# Altium schematic sheet Output_2.SchDoc(Output_2)
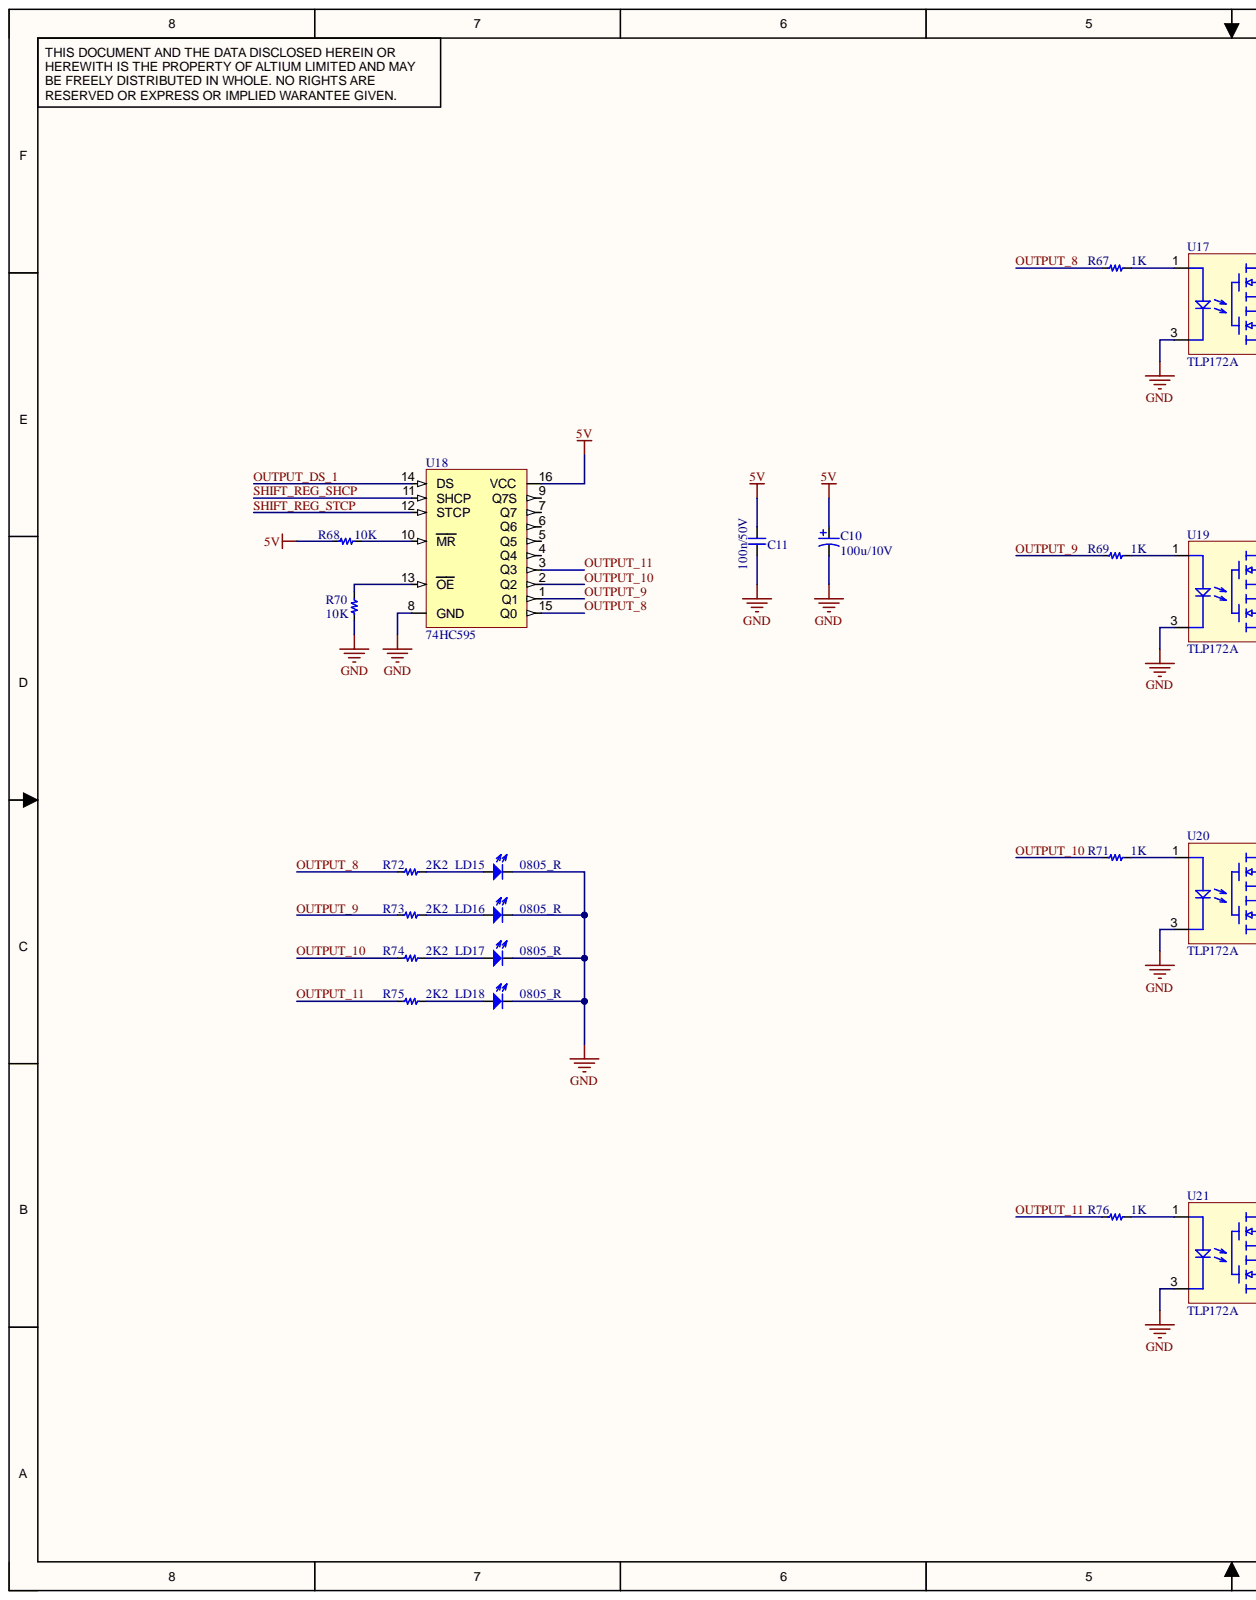
[diagram p0: Output_2.SchDoc, left]
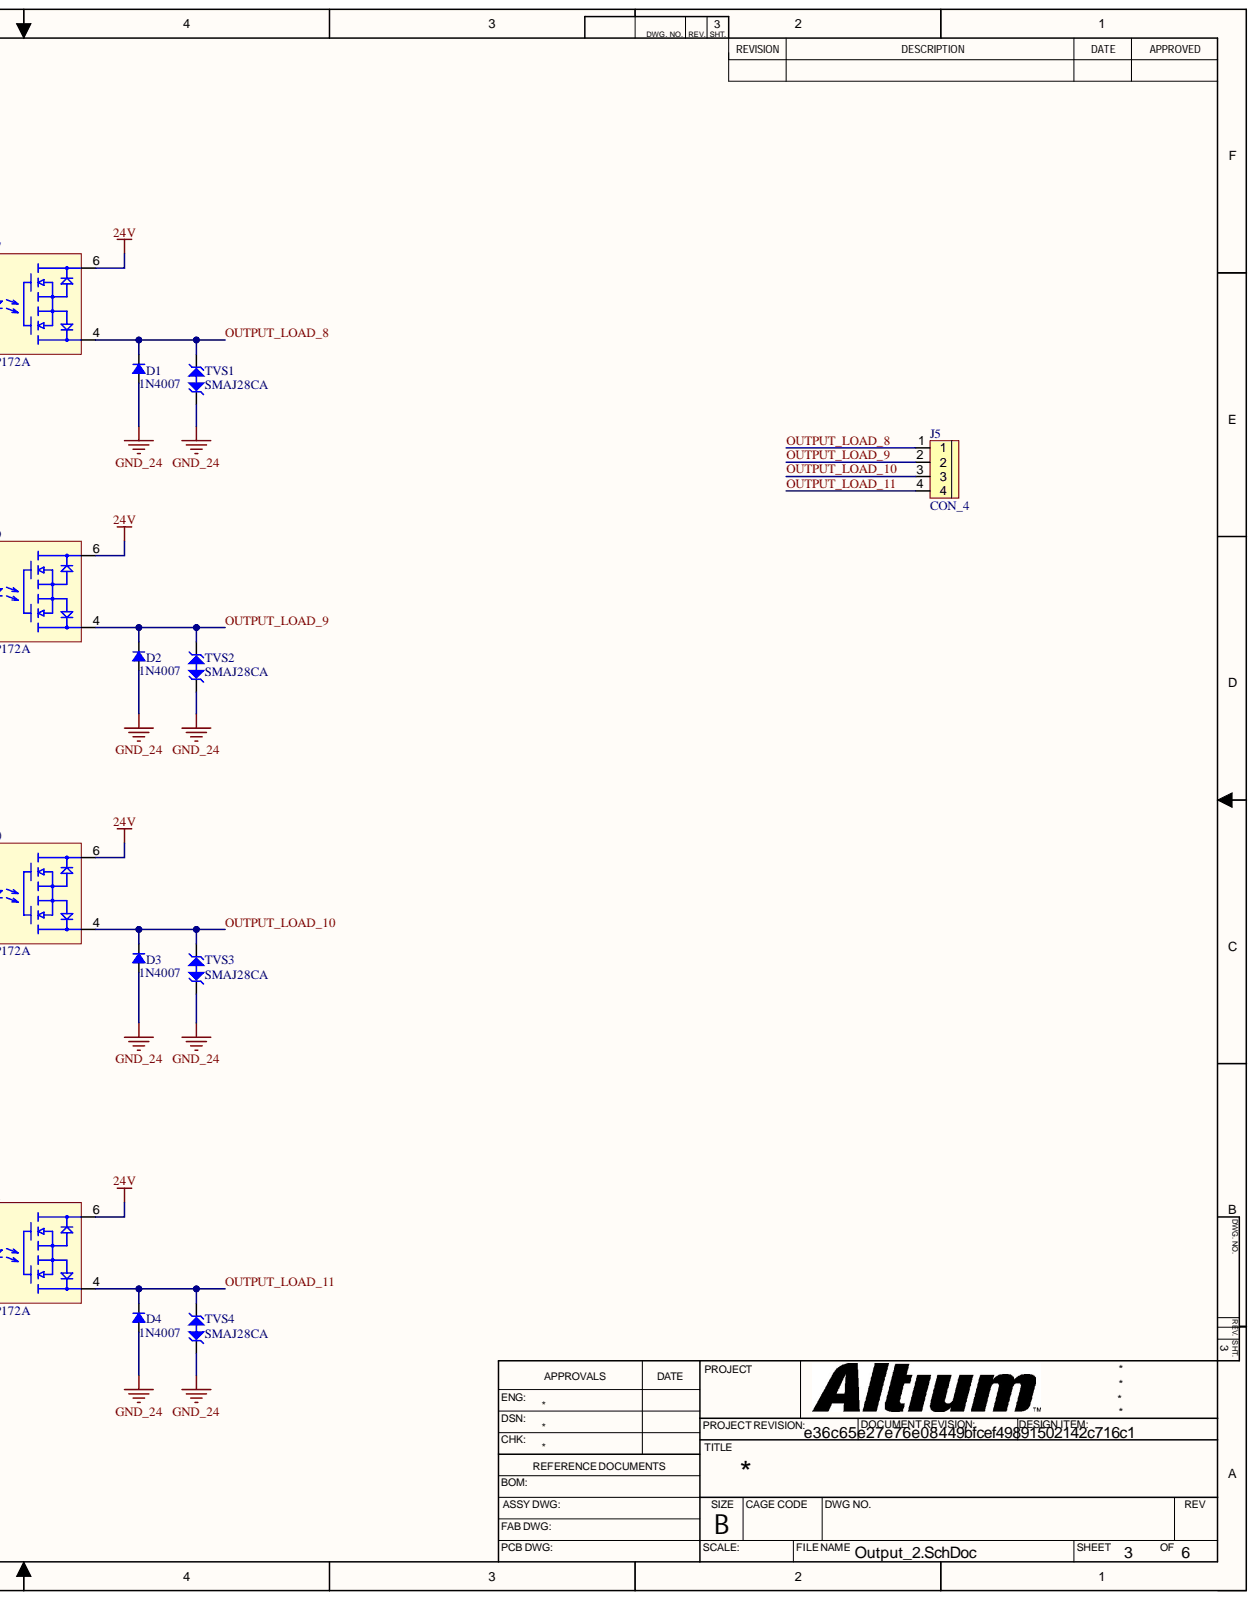
[diagram p1: Output_2.SchDoc, right]

## Parts
C10  100u/10V  [CAP_TANTA_CASE_B]
C11  100n/50V  [C-0805]
D1  1N4007  [SMA]
D2  1N4007  [SMA]
D3  1N4007  [SMA]
D4  1N4007  [SMA]
J5  CON_4  [KF-5.0-4P]
LD15  0805_R  [LED_0805_R]
LD16  0805_R  [LED_0805_R]
LD17  0805_R  [LED_0805_R]
LD18  0805_R  [LED_0805_R]
R67  1K  [R-0805]
R68  10K  [R-0805]
R69  1K  [R-0805]
R70  10K  [R-0805]
R71  1K  [R-0805]
R72  2K2  [R-0805]
R73  2K2  [R-0805]
R74  2K2  [R-0805]
R75  2K2  [R-0805]
R76  1K  [R-0805]
TVS1  SMAJ28CA  [TVS_BIDI]
TVS2  SMAJ28CA  [TVS_BIDI]
TVS3  SMAJ28CA  [TVS_BIDI]
TVS4  SMAJ28CA  [TVS_BIDI]
U17  TLP172A  [SO - 4]
U18  74HC595  [SOIC-16-D]
U19  TLP172A  [SO - 4]
U20  TLP172A  [SO - 4]
U21  TLP172A  [SO - 4]

## Nets
5V: C10.1 C11.2 R68.2 U18.16(VCC)
24V: U17.6 U19.6 U20.6 U21.6
GND: C10.2 C11.1 LD15.2 LD16.2 LD17.2 LD18.2 R70.2 U17.3 U18.8(GND) U19.3 U20.3 U21.3
GND_24: D1.1 D2.1 D3.1 D4.1 TVS1.1 TVS2.1 TVS3.1 TVS4.1
OUTPUT_8: R67.2 R72.2 U18.15(Q0)
OUTPUT_9: R69.2 R73.2 U18.1(Q1)
OUTPUT_10: R71.2 R74.2 U18.2(Q2)
OUTPUT_11: R75.2 R76.2 U18.3(Q3)
OUTPUT_DS_1: U18.14(DS)
OUTPUT_LOAD_8: D1.2 J5.1 TVS1.2 U17.4
OUTPUT_LOAD_9: D2.2 J5.2 TVS2.2 U19.4
OUTPUT_LOAD_10: D3.2 J5.3 TVS3.2 U20.4
OUTPUT_LOAD_11: D4.2 J5.4 TVS4.2 U21.4
SHIFT_REG_SHCP: U18.11(SHCP)
SHIFT_REG_STCP: U18.12(STCP)
NetLD15_1: LD15.1 R72.1
NetLD16_1: LD16.1 R73.1
NetLD17_1: LD17.1 R74.1
NetLD18_1: LD18.1 R75.1
NetR67_1: R67.1 U17.1
NetR68_1: R68.1 U18.10(MR)
NetR69_1: R69.1 U19.1
NetR70_1: R70.1 U18.13(OE)
NetR71_1: R71.1 U20.1
NetR76_1: R76.1 U21.1

Not connected: U18 4(Q4) 5(Q5) 6(Q6) 7(Q7) 9(Q7S)

## Library data of the parts
C10 = TANTALUM_CAPACITOR: Tantalum Capacitors; Component Kind: Standard; Library Name: Schlib1.SchLib
C11 = CERAMIC_CAP: Component Kind: Standard; Library Name: NXVu.SchLib
D1-D4 = DIODE: Component Kind: Standard; Library Name: NXVu.SchLib
LD15-LD18 = LED_0805_R: Component Kind: Standard; Library Name: NXVu.SchLib
R67-R76 = RESISTOR: Component Kind: Standard; Library Name: NXVu.SchLib
TVS1-TVS4 = TVS_Bidirection: Component Kind: Standard; Library Name: NXVu.SchLib
U17 U19-U21 = TLP172A: Solid State SPST-NO(1 Form A) SOP-4-2.54mm; Component Kind: Standard; Library Name: NXVu.SchLib
U18 = 74HC595: 2V~6V 1 19ns@4.5V,50pF Serial-to-Serial or Parallel SOIC-16 Shift Registers RoHS; Component Kind: Standard; Library Name: NXVu.SchLib
J5 = CON_4: Component Kind: Standard; Library Name: NXVu.SchLib
